# Altium schematic sheet 摄像头.SchDoc
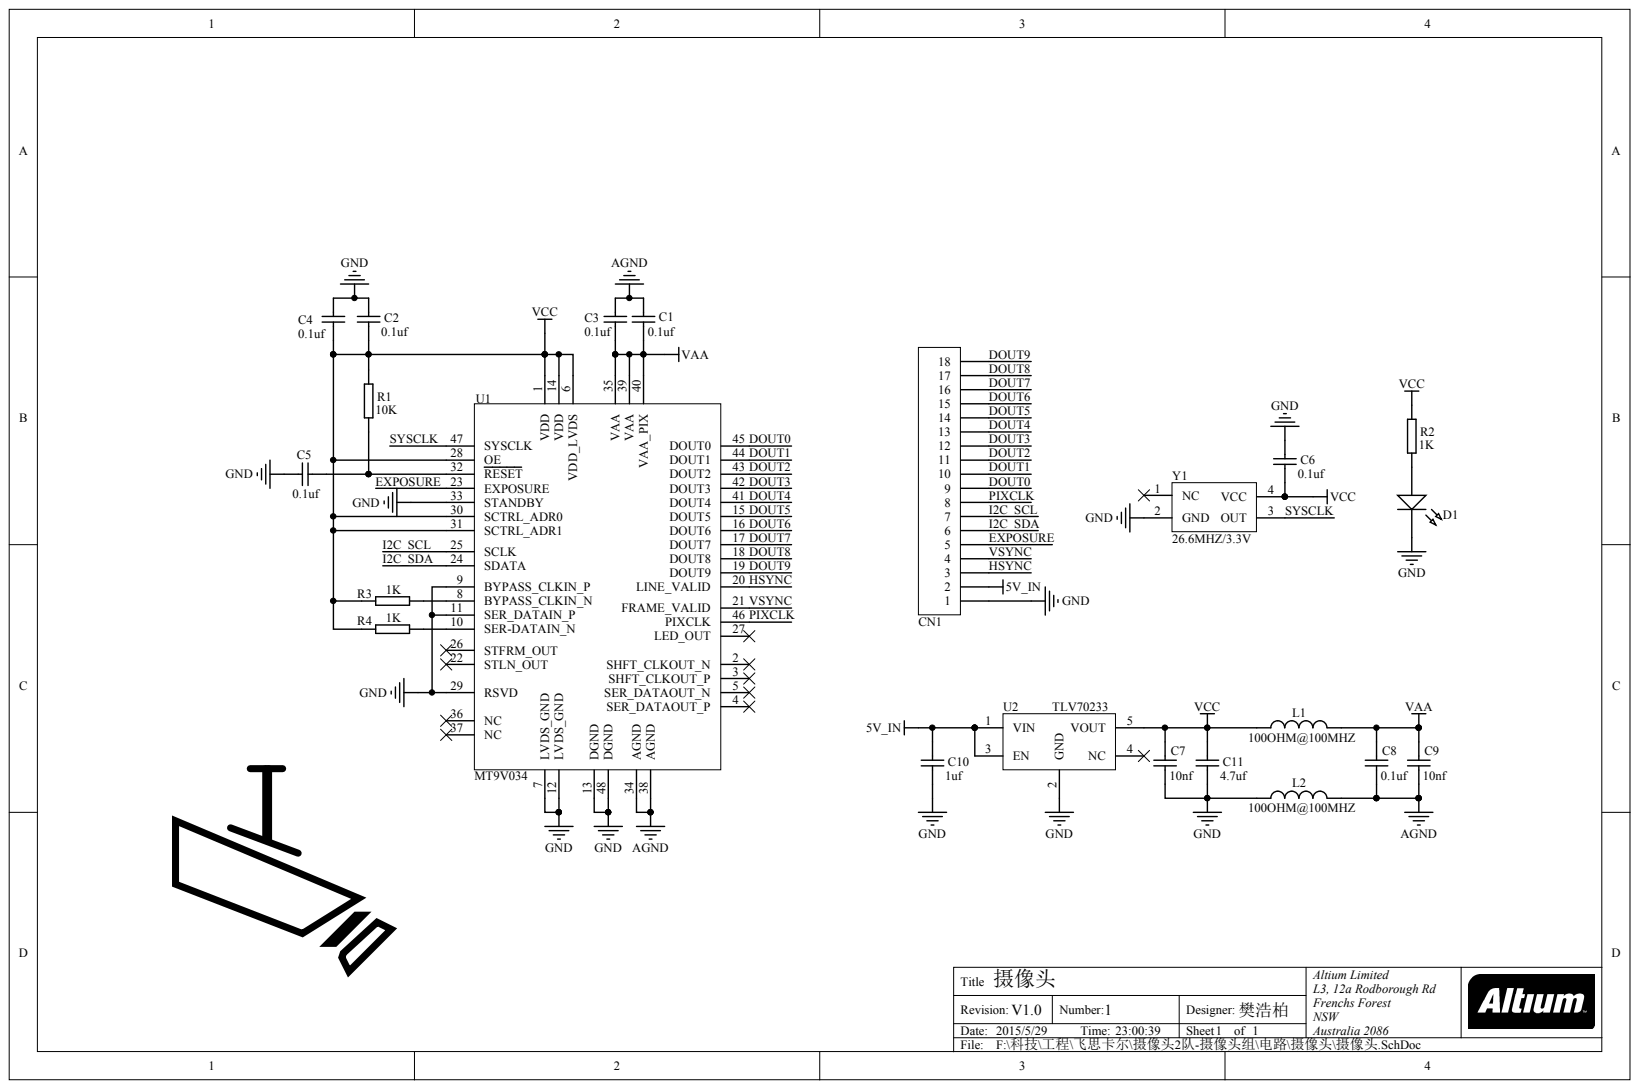
[diagram p0: 摄像头.SchDoc]

## Parts
C1  0.1uf
C2  0.1uf
C3  0.1uf
C4  0.1uf
C5  0.1uf
C6  0.1uf
C7  10nf
C8  0.1uf
C9  10nf
C10  1uf
C11  4.7uf
Camera  Camera  (value not drawn)
CN1  CON-18X1  (value not drawn)
D1  LED  (value not drawn)
L1  100OHM@100MHZ
L2  100OHM@100MHZ
R1  10K
R2  1K
R3  1K
R4  1K
U1  MT9V034
U2  TLV70233
Y1  26.6MHZ/3.3V

## Nets
5V_IN: C10.1 CN1.2 U2.1(VIN) U2.3(EN)
AGND: C1.1 C3.2 C8.2 C9.2 L2.2 U1.34(AGND) U1.38(AGND)
GND: C2.1 C4.1 C5.1 C6.1 C7.2 C10.2 C11.2 CN1.1 D1.K L2.1 U1.7(LVDS_GND) U1.9(BYPASS_CLKIN_P) U1.11(SER_DATAIN_P) U1.12(LVDS_GND) U1.13(DGND) U1.29(RSVD) U1.33(STANDBY) U1.48(DGND) U2.2(GND) Y1.2(GND)
VAA: C1.2 C3.1 C8.1 C9.1 L1.2 U1.35(VAA) U1.39(VAA) U1.40(VAA_PIX)
VCC: C2.2 C4.2 C6.2 C7.1 C11.1 L1.1 R1.2 R2.2 R3.1 R4.1 U1.1(VDD) U1.6(VDD_LVDS) U1.14(VDD) U1.28(OE) U1.30(SCTRL_ADR0) U1.31(SCTRL_ADR1) U2.5(VOUT) Y1.4(VCC)
DOUT0: CN1.9 U1.45(DOUT0)
DOUT1: CN1.10 U1.44(DOUT1)
DOUT2: CN1.11 U1.43(DOUT2)
DOUT3: CN1.12 U1.42(DOUT3)
DOUT4: CN1.13 U1.41(DOUT4)
DOUT5: CN1.14 U1.15(DOUT5)
DOUT6: CN1.15 U1.16(DOUT6)
DOUT7: CN1.16 U1.17(DOUT7)
DOUT8: CN1.17 U1.18(DOUT8)
DOUT9: CN1.18 U1.19(DOUT9)
EXPOSURE: CN1.5 U1.23(EXPOSURE)
HSYNC: CN1.3 U1.20(LINE_VALID)
I2C_SCL: CN1.7 U1.25(SCLK)
I2C_SDA: CN1.6 U1.24(SDATA)
PIXCLK: CN1.8 U1.46(PIXCLK)
SYSCLK: U1.47(SYSCLK) Y1.3(OUT)
VSYNC: CN1.4 U1.21(FRAME_VALID)
NetC5_2: C5.2 R1.1 U1.32(RESET)
NetD1_A: D1.A R2.1
NetR3_2: R3.2 U1.8(BYPASS_CLKIN_N)
NetR4_2: R4.2 U1.10(SER-DATAIN_N)

Not connected: U1 2(SHFT_CLKOUT_N) 3(SHFT_CLKOUT_P) 4(SER_DATAOUT_P) 5(SER_DATAOUT_N) 22(STLN_OUT) 26(STFRM_OUT) 27(LED_OUT) 36(NC) 37(NC); U2 4(NC); Y1 1(NC)

## Library data of the parts
C1-C11 Camera CN1 D1 L1 L2 R1-R4 U1 U2 Y1 = (no symbol name)
  U2: Published: 24-Mar-1999; LatestRevisionDate: 29-May-2009; LatestRevisionNote: IPC-7351 Footprint Added.; PackageReference: D2PAK; PackageDescription: 3-Pin Transistor Outline Package 2.54 mm Pitch, 15.1 mm Lead Span; Publisher: Altium Limited
